# Altium schematic sheet Peripheral.SchDoc
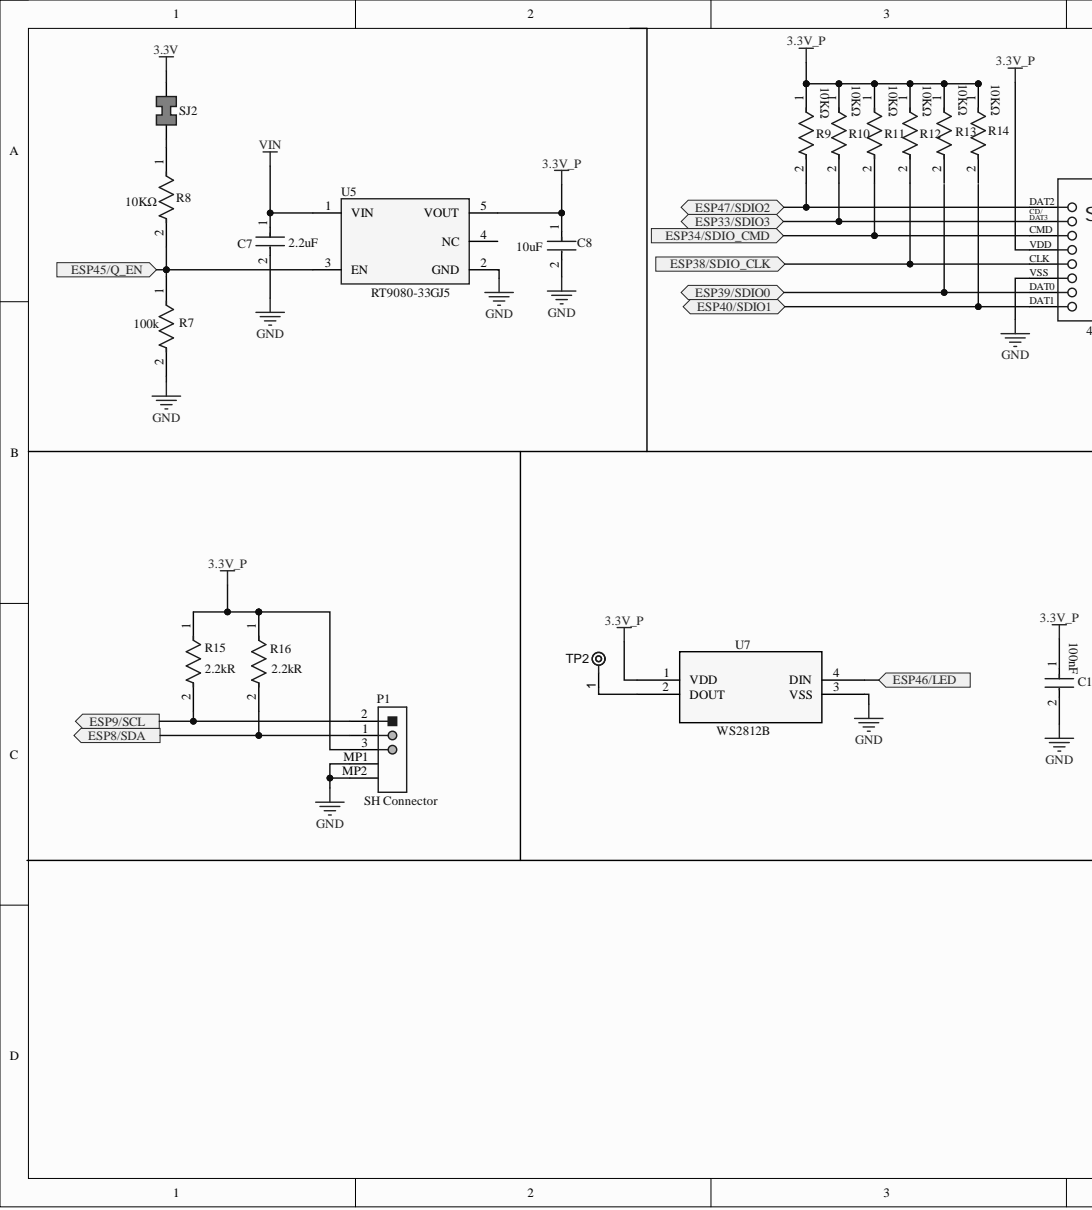
[diagram p0: Peripheral.SchDoc, left]
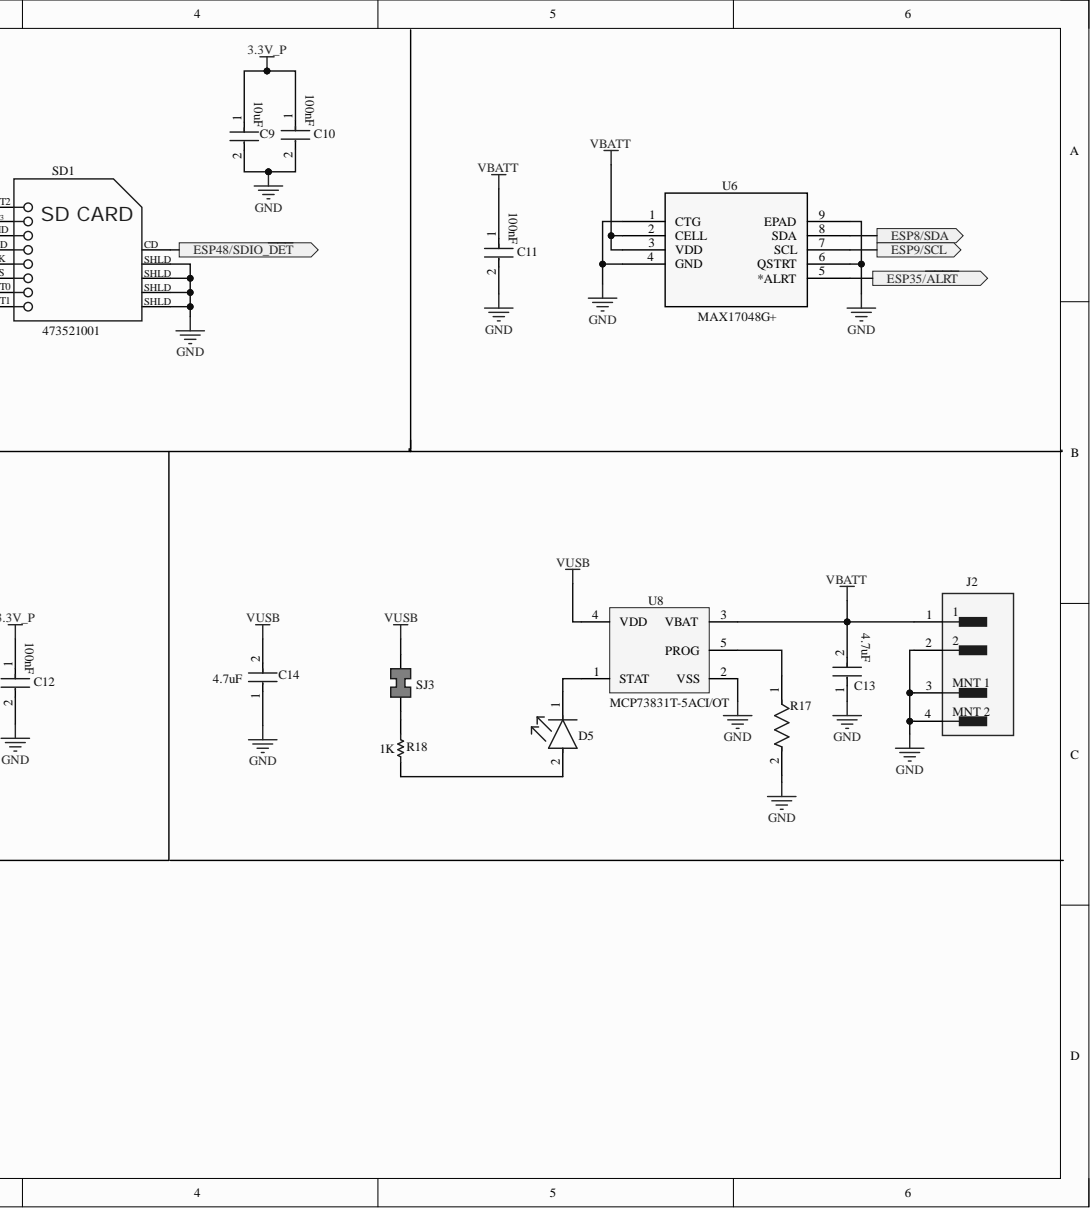
[diagram p1: Peripheral.SchDoc, right]

## Parts
C7  Capacitance: 2.2uF  [FP-GRM155-0_1-IPC_C]  (hidden comment: GRM155C81A225KE44D)
C8  Capacitance: 10uF  [FP-CL21-IPC_C]  (hidden comment: CL21A106MQFNNNE)
C9  Capacitance: 10uF  [FP-CL21-IPC_C]  (hidden comment: CL21A106MQFNNNE)
C10  Capacitance: 100nF  [FP-GRM033-0_03-IPC_B]  (hidden comment: GRM033C70J104KE14D)
C11  Capacitance: 100nF  [FP-GRM033-0_03-IPC_B]  (hidden comment: GRM033C70J104KE14D)
C12  Capacitance: 100nF  [FP-GRM033-0_03-IPC_B]  (hidden comment: GRM033C70J104KE14D)
C13  Capacitance: 4.7uF  [FP-0402-L_1_0_0_15-W_0_5-IPC_C]  (hidden comment: JMK105BC6475MV-F)
C14  Capacitance: 4.7uF  [FP-GRM219-0_15-0_1-IPC_C]  (hidden comment: GRM219R6YA475MA73D)
D5  LTST-C191KSKT  [FP-LTST-C191KSKT-MFG]  (value not drawn)
J2  SM02B-SRSS-TB (LF)(SN)  [FP-SM02B-SRSS-TB_LF_SN-MFG]  (value not drawn)
P1  SH Connector  [JST-SM03B-SRSS-TB_V]
R7  Resistance: 100k  [FP-CRCW0402-C-e3-MFG]  (hidden comment: CRCW0402100KFKEDC)
R8  value 10KΩ  Resistance: 10KΩ  [FP-RT0201-MFG]  (hidden comment: RT0201FRE0710KL)
R9  value 10KΩ  Resistance: 10KΩ  [FP-RT0201-MFG]  (hidden comment: RT0201FRE0710KL)
R10  value 10KΩ  Resistance: 10KΩ  [FP-RT0201-MFG]  (hidden comment: RT0201FRE0710KL)
R11  value 10KΩ  Resistance: 10KΩ  [FP-RT0201-MFG]  (hidden comment: RT0201FRE0710KL)
R12  value 10KΩ  Resistance: 10KΩ  [FP-RT0201-MFG]  (hidden comment: RT0201FRE0710KL)
R13  value 10KΩ  Resistance: 10KΩ  [FP-RT0201-MFG]  (hidden comment: RT0201FRE0710KL)
R14  value 10KΩ  Resistance: 10KΩ  [FP-RT0201-MFG]  (hidden comment: RT0201FRE0710KL)
R15  Resistance: 2.2kR  [FP-CRCW0402-e3-IPC_B]  (hidden comment: CRCW04022K20FKEDC)
R16  Resistance: 2.2kR  [FP-CRCW0402-e3-IPC_B]  (hidden comment: CRCW04022K20FKEDC)
R17  CR0402AFX-2001GLF  [FP-CR0402A-IPC_A]  (value not drawn)
R18  Resistance: 1K  [RESC1005X40X25ML10T10]  (hidden comment: 1-1622826-8)
SD1  473521001  [MOLX-473521001_V]
SJ2  Solder Jumper  [Solder Jumper-Footprint-1]  (value not drawn)
SJ3  Solder Jumper  [Solder Jumper-Footprint-1]  (value not drawn)
TP2  TPAD6R  [TP06R]  (value not drawn)  (no BOM)
U5  RT9080-33GJ5  [FP-RT9080-33GJ5-IPC_C]
U6  MAX17048G+  [21-0168_T822+3_MXM]
U7  WS2812B  [WS2812B_WDS]
U8  MCP73831T-5ACI/OT  [SOT-23-OT5_N]

## Ports
ESP8/SDA, ESP9/SCL, ESP33/SDIO3, ESP34/SDIO_CMD, ESP35/~{ALRT}, ESP38/SDIO_CLK, ESP39/SDIO0, ESP40/SDIO1, ESP45/Q_EN, ESP46/LED, ESP47/SDIO2, ESP48/SDIO_~{DET}

## Nets
3.3V: SJ2.1
3.3V_P: C8.1 C9.1 C10.1 C12.1 P1.3 R9.1 R10.1 R11.1 R12.1 R13.1 R14.1 R15.1 R16.1 SD1.4 U5.5(VOUT) U7.1(VDD)
GND: C7.2 C8.2 C9.2 C10.2 C11.2 C12.2 C13.1 C14.1 J2.2 J2.3 J2.4 P1.MP1 P1.MP2 R7.2 R17.2 SD1.6 SD1.10 SD1.11 SD1.12 SD1.13 U5.2(GND) U6.1(CTG) U6.4(GND) U6.6(QSTRT) U6.9(EPAD) U7.3(VSS) U8.2(VSS)
VBATT: C11.1 C13.2 J2.1 U6.2(CELL) U6.3(VDD) U8.3(VBAT)
VIN: C7.1 U5.1(VIN)
VUSB: C14.2 SJ3.1 U8.4(VDD)
ESP8/SDA: P1.1 R16.2 U6.8(SDA) ; port ESP8/SDA
ESP9/SCL: P1.2 R15.2 U6.7(SCL) ; port ESP9/SCL
ESP33/SDIO3: R10.2 SD1.2(SD_CARD) ; port ESP33/SDIO3
ESP34/SDIO_CMD: R11.2 SD1.3 ; port ESP34/SDIO_CMD
ESP35/~{ALRT}: U6.5(*ALRT) ; port ESP35/~{ALRT}
ESP38/SDIO_CLK: R12.2 SD1.5 ; port ESP38/SDIO_CLK
ESP39/SDIO0: SD1.7 ; port ESP39/SDIO0
ESP40/SDIO1: SD1.8 ; port ESP40/SDIO1
ESP45/Q_EN: R7.1 R8.2 U5.3(EN) ; port ESP45/Q_EN
ESP46/LED: U7.4(DIN) ; port ESP46/LED
ESP47/SDIO2: R9.2 SD1.1 ; port ESP47/SDIO2
ESP48/SDIO_~{DET}: SD1.9 ; port ESP48/SDIO_~{DET}
NetD5_1: D5.1 U8.1(STAT)
NetD5_2: D5.2 R18.2
NetR8_1: R8.1 SJ2.2
NetR17_1: R17.1 U8.5(PROG)
NetR18_1: R18.1 SJ3.2
NetTP2_1: TP2.1 U7.2(DOUT)

Not connected: R13 2; R14 2; U5 4(NC)

## Library data of the parts
C7 = CMP-06035-004415-1: Chip Multilayer Ceramic Capacitors for General Purpose, 0402, 2.2uF, X6S, 22%, 10%, 10V; Case Code (Imperial): 0402; Case Code (Metric): 1005; Case/Package: 0402; Component Kind: Standard; Dielectric: X6S; Height: 0.5mm; Length: 0.039inch; Library Name: Altium Content Vault; Max Operating Temperature: 105°C; Min Operating Temperature: -55°C; Mount: Surface Mount; Package Quantity: 10000; Packaging: Tape and Reel; Termination: SMD/SMT; Thickness: 0.022inch; Tolerance: 10%; Voltage Rating: 10V; Voltage Rating (DC): 10V; Weight: 0.000212oz; Width: 0.02inch
C8 C9 = CMP-13271-000780-1: CL21 Series 0805 10uF 6.3V ±20% Tolerance X5R Multilayer Ceramic Chip Capacitor; Case Code (Imperial): 0805; Case Code (Metric): 2012; Case/Package: 0805; Component Kind: Standard; Depth: 1.25mm; Dielectric: X5R; Height: 1.25mm; Lead Free: Lead Free; Length: 2mm; Library Name: Altium Content Vault; Max Operating Temperature: 85°C; Min Operating Temperature: -55°C; Mount: Surface Mount; Packaging: Tape and Reel; Radiation Hardening: No; Thickness: 0.053inch; Tolerance: 20%; Voltage Rating: 6.3V; Voltage Rating (DC): 6.3V; Width: 0.049inch
C10-C12 = CMP-06035-000516-1: Chip Multilayer Ceramic Capacitors for General Purpose, 0201, 0.10uF, X7S, 22%, 10%, 6.3V; Component Kind: Standard; Dielectric: X7S; Length: 600um; Library Name: Altium Content Vault; Thickness: 300um; Tolerance: 10%; Voltage Rating (DC): 6.3V; Width: 300um
C13 = CMP-14477-001053-2: None; Tolerance: 20%; Voltage Rating: 6.3V; Case Code (Imperial): 0402; Case Code (Metric): 1005; Case/Package: 0402; Component Kind: Standard; Lead Free: Lead Free; Library Name: Altium Content Vault; Max Operating Temperature: 105°C; Min Operating Temperature: -55°C; Mount: Surface Mount; Packaging: Tape and Reel; RoHS Compliant: Yes
C14 = CMP-2007-00095-2: Chip Multilayer Ceramic Capacitors for General Purpose, 0805, 4.7uF, X5R, 15%, 20%, 35V; Case Code (Imperial): 0805; Case Code (Metric): 2012; Case/Package: 0805; Component Kind: Standard; Depth: 1.25mm; Dielectric: X5R; Height: 0.85mm; Lead Free: Lead Free; Length: 2mm; Library Name: Altium Content Vault; Max Operating Temperature: 85°C; Min Operating Temperature: -55°C; Mount: Surface Mount; Package Quantity: 4000; Packaging: Tape and Reel; REACH SVHC: No SVHC; Termination: SMD/SMT; Thickness: 0.037inch; Tolerance: 20%; Voltage: 35V; Voltage Rating: 35V; Voltage Rating (DC): 35V; Width: 1.25mm
D5 = CMP-22017-000025-1: LED YELLOW CLEAR SMD; Dominant Wavelength: 597nm; Forward Current: 30mA; Forward Voltage: 2.4V; Lens Color: Colorless; Lens Style: Diffused; Luminous Intensity: 180mcd; Number of Elements: 1; Power Dissipation: 75mW; Reverse Voltage: 5V; Test Current: 20mA; Viewing Angle: 130°; Wavelength: 588nm; Case Code (Imperial): 0603; Case Code (Metric): 1608; Case/Package: 0603; Color: Yellow; Component Kind: Standard; Depth: 0.8mm; Height: 0.55mm; Illumination Color: Yellow; Lead Free: Lead Free; Length: 1.6mm; Lens Transparency: Clear; Library Name: Altium Content Vault; Max Operating Temperature: 85°C; Min Operating Temperature: -55°C; Mount: Surface Mount; Number of LEDs: 1; Number of Pins: 2; Package Quantity: 1; Packaging: Tape and Reel; Power Rating: 75mW; Radiation Hardening: No; Width: 0.8mm
J2 = CMP-17439-000368-1: CONN HEADER SMD R/A 2POS 1MM; Contact Resistance: 40mR; Current Rating: 700mA; Insulation Resistance: 100MR; Max Current Rating: 1A; Max Voltage Rating (AC): 50V; Number of Contacts: 2; Color: Natural; Component Kind: Standard; Connector Type: Header; Contact Finish - Mating: TIN; Contact Material: Copper Alloy; Contact Plating: Tin; Contact Shape: Square; Contact Type: Male Pin; Depth: 4.95mm; Fastening Type: Pull; Flammability Rating: UL94 V-0; Housing Color: Beige; Housing Material: Polyamide; Insulation Color: Natural; Insulation Material: Nylon; Lead Free: Lead Free; Lead Pitch: 1mm; Length: 4mm; Library Name: Altium Content Vault; Material: Polyamide; Max Operating Temperature: 85°C; Min Operating Temperature: -25°C; Mount: Surface Mount; Number of Positions: 2; Number of Rows: 1; Orientation: Right Angle; Packaging: Tape and Reel; Pitch: 1mm; REACH SVHC: No SVHC; Series: SR; Termination: Solder; Voltage Rating: 50V; Voltage Rating (AC): 50V
P1 = CMP-2000-07077-1: Male Header, Pitch 1 mm, 1 x 3 Position, Height 2.9 mm, -25 to 85 degC, RoHS, Tape and Reel; Manufacturer: JST; No of Positions: 3; Pitch: 1mm; Style/Orientation: RightAngleHeader/Plug; Component Kind: Standard; Datasheet URL: https://datasheet.ciiva.com/26894/getdatasheetpartid-708885-26894671.pdf; Datasheet Version: 11/2010; Library Name: Altium Content Vault; Manufacturer URL: http://www.jst.com/home2.html; Mounting Technology: SurfaceMount; Package Description: Male Header, Pitch 1 mm, 1 x 3 Position, Height 2.9 mm; Package Reference: SM03B-SRSS-TB; Termination: Crimp; Type: ShroudedHeader
R7 = CMP-02407-010951-1: RES 100K OHM 1% 1/16W 0402; Power Rating: 62.5mW; Temperature Coefficient: 100ppm/°C; Case/Package: SMD/SMT; Component Kind: Standard; Contact Plating: Tin; Lead Free: Lead Free; Length: 1mm; Library Name: Altium Content Vault; Max Operating Temperature: 155°C; Min Operating Temperature: -55°C; Mount: Surface Mount; Resistor Type: Thick Film; RoHS Compliant: Yes; Termination: Wraparound; Tolerance: 1%
R8-R14 = CMP-03412-013100-1: RES SMD 10K OHM 1% 1/20W 0201; Manufacturer: Yageo; Power Rating: 0.05W; Temperature Coefficient: 50 ppm/°C; Tolerance: 0.01; Voltage Rating: 25V; Automotive: No; Category: Res; Component Kind: Standard; ComponentLink2Description: Datasheet; ComponentLink2URL: http://www.yageo.com/documents/recent/PYu-RT_1-to-0.01_RoHS_L_9.pdf; Composition: Thin Film; Device Class L1: Passive Components; Device Class L2: Resistors; Device Class L3: Chip SMD Resistors; Digikey Description: RES SMD 10K OHM 1% 1/20W 0201; Footprint Url: http://www.yageo.com/exep/pages/download/literatures/PYu-R_Mount_10.pdf; Height: 0.26mm; Ipc Land Pattern Name: RESC6030X23; Lead Free: Yes; Library Name: Altium Content Vault; Manufacturer Part Number: RT0201FRE0710KL; Mouser Description: Res Thin Film 0201 10K Ohm 1% 1/20W ±50ppm/°C Molded SMD Paper T/R; Octopart: https://octopart.com/rt0201fre0710kl-yageo-71392129; Package: RT0201; RoHS: TRUE; Series: RT; Temperature: -55°C to +125°C; Temperature Range High: +125°C; Temperature Range Low: -55°C
R15 R16 = CMP-02407-013149-1: RES 2.2K OHM 1% 1/16W 0402; Max Power Dissipation: 63mW; Power Rating: 62.5mW; Temperature Coefficient: 100ppm/°C; Case Code (Imperial): 0402; Case Code (Metric): 1005; Case/Package: 0402; Component Kind: Standard; Contact Plating: Tin; Lead Free: Lead Free; Length: 1mm; Library Name: Altium Content Vault; Max Operating Temperature: 155°C; Min Operating Temperature: -55°C; Mount: Surface Mount; Number of Terminations: 2; Packaging: Cut Tape; Resistor Type: Thick Film; RoHS Compliant: Yes; Series: CRCW-C; Termination: Wraparound; Tolerance: 1%
R17 = CMP-07231-001734-1: AEC-Q200 Compliant Chip Resistors 0402 2kΩ 0.063W 1% 100ppm/°C; Component Kind: Standard; Library Name: Altium Content Vault
R18 = CMP-2002-04643-1: Manufacturer: TE Connectivity / AMP; Component Kind: Standard; Library Name: Altium Content Vault; Part Number: 1-1622826-8
SD1 = CMP-2000-05848-1: MicroSD(TM), Pitch 1.1 mm, 8 Position, Height 1.88 mm, -20 to 85 degC, RoHS, Tape and Reel; Manufacturer: Molex; Component Kind: Standard; Datasheet URL: http://www.molex.com/pdm_docs/sd/473521001_sd.pdf; Datasheet Version: Rev. G, 01/2012; Library Name: Altium Content Vault; Manufacturer URL: http://www.molex.com/; Mounting Technology: SurfaceMount; Package Description: MicroSD(TM), Pitch 1.1 mm, 8 Position, Height 1.88 mm; Package Reference: 473521001; Supplier: Arrow Electronics; Supplier Part Number: 0473521001
SJ2 SJ3 = CMP-00030-00001-3: 2 pad Solder Jumper; Case/Package: 1206; Component Kind: Standard; Max Operating Temperature: 155°C; Min Operating Temperature: -55°C; Mounting Technology: Surface Mount; Pins: 2; Library Name: Shuvendu Das
TP2 = CMP-00028-00002-2: 0.6mm round; Pins: 1; Library Name: Shuvendu Das
U5 = CMP-79890-000003-1: IC REG LIN 3.3V 600MA TSOT23-5; Dropout Voltage: 530mV; Max Input Voltage: 5.5V; Number of Regulators: 1; Output Configuration: Positive; Output Type: Fixed; Power Supply Rejection Ratio (PSRR): 75dB; Quiescent Current: 4uA; Case/Package: SOT-23-5; Component Kind: Standard; Library Name: Altium Content Vault; Max Operating Temperature: 85°C; Min Operating Temperature: -40°C; Min Output Voltage: 3.3V; Mount: Surface Mount; Output Current: 600mA; Packaging: Cut Tape; RoHS Compliant: Yes
U6 = MAX17048G+: 3ÂµA 1-Cell/2-Cell Fuel Gauge with ModelGauge; Manufacturer_Name: Analog Devices Inc; Component Kind: Standard; Library Name: MAX17048G&plus_.SchLib; Manufacturer_Part_Number: MAX17048G+; RefDes: RefDes; Supplier 1: DigiKey; Supplier Part Number 1: MAX17048G+T10CT-ND; Type: Type; Copyright: Copyright (C) 2025 Ultra Librarian. All rights reserved.
U7 = WS2812B: No Description Available; Manufacturer_Name: Worldsemi; Component Kind: Standard; Library Name: WS2812B.SchLib; Manufacturer_Part_Number: WS2812B; RefDes: RefDes; Supplier 1: DigiKey; Supplier Part Number 1: 28085-ND; Type: Type; Copyright: Copyright (C) 2025 Ultra Librarian. All rights reserved.
U8 = CMP-0133-00136-1: Miniature Single-Cell, Fully Integrated Li-Ion, Li-Polymer Charge Management Controller, 4.5V, 5-Pin SOT-23, Industrial Temperature, Tape and Reel; Code_IPC: SOT23-5; Component Kind: Standard; DatasheetVersion: revE, Sep-2008; Library Name: Altium Content Vault; PackageDescription: 5-Lead Plastic Small Outline Transistor (OT) [SOT-23]; PackageReference: SOT-23-OT5; PackageVersion: revBB, Aug-2009
